# Altium schematic sheet OpAmps.SchDoc(OpAmps)
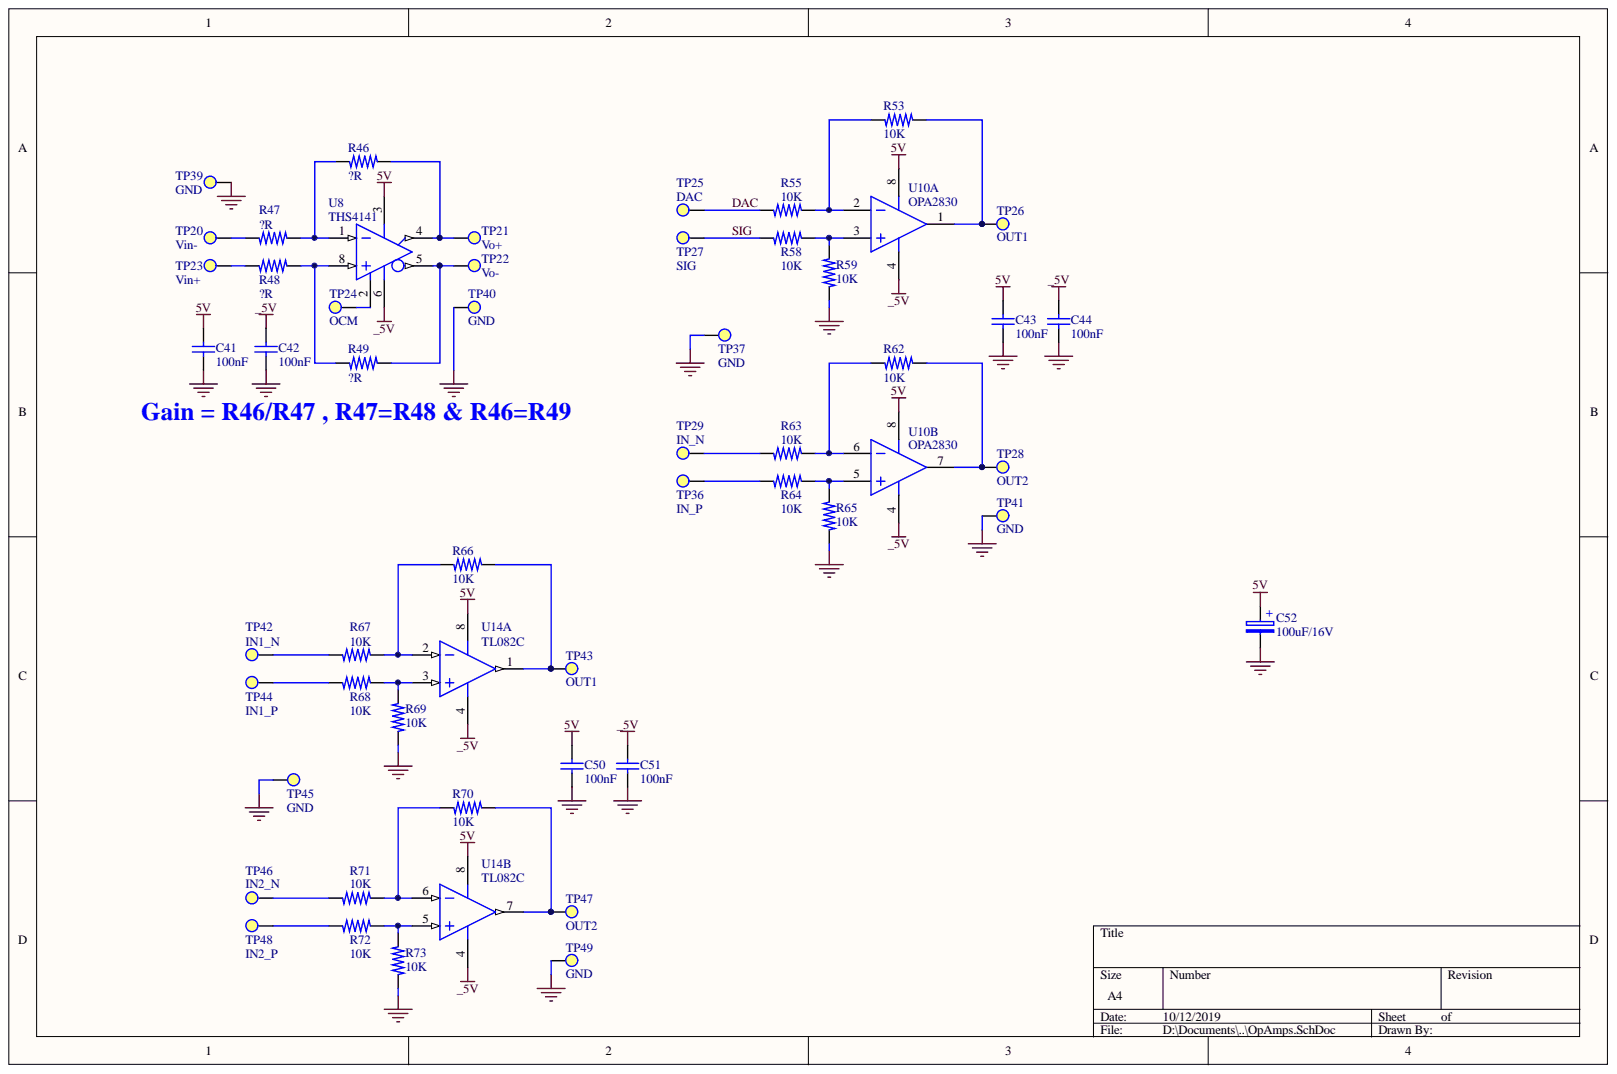
[diagram p0: OpAmps.SchDoc]

## Parts
C41  100nF  [0603_C]
C42  100nF  [0603_C]
C43  100nF  [0805Big_C]
C44  100nF  [0805Big_C]
C50  100nF  [0805Big_C]
C51  100nF  [0805Big_C]
C52  100uF/16V  [CAP_RAD_P04_D8x11]
R46  ?R  [0805Big_R]
R47  ?R  [0805Big_R]
R48  ?R  [0805Big_R]
R49  ?R  [0805Big_R]
R53  10K  [0805Big_R]
R55  10K  [0805Big_R]
R58  10K  [0805Big_R]
R59  10K  [0805Big_R]
R62  10K  [0805Big_R]
R63  10K  [0805Big_R]
R64  10K  [0805Big_R]
R65  10K  [0805Big_R]
R66  10K  [0805Big_R]
R67  10K  [0805Big_R]
R68  10K  [0805Big_R]
R69  10K  [0805Big_R]
R70  10K  [0805Big_R]
R71  10K  [0805Big_R]
R72  10K  [0805Big_R]
R73  10K  [0805Big_R]
TP20  Vin-  [PIN0MM9]
TP21  Vo+  [PIN0MM9]
TP22  Vo-  [PIN0MM9]
TP23  Vin+  [PIN0MM9]
TP24  OCM  [PIN0MM9]
TP25  DAC  [PIN0MM9]
TP26  OUT1  [PIN0MM9]
TP27  SIG  [PIN0MM9]
TP28  OUT2  [PIN0MM9]
TP29  IN_N  [PIN0MM9]
TP36  IN_P  [PIN0MM9]
TP37  GND  [PIN0MM9]
TP39  GND  [PIN0MM9]
TP40  GND  [PIN0MM9]
TP41  GND  [PIN0MM9]
TP42  IN1_N  [PIN0MM9]
TP43  OUT1  [PIN0MM9]
TP44  IN1_P  [PIN0MM9]
TP45  GND  [PIN0MM9]
TP46  IN2_N  [PIN0MM9]
TP47  OUT2  [PIN0MM9]
TP48  IN2_P  [PIN0MM9]
TP49  GND  [PIN0MM9]
U8  THS4141  [SOIC-8]
U10  OPA2830  [MSOP-8]  (parts A,B)
U14  TL082C  [SOIC-8]  (parts A,B)

## Nets
5V: C41.1 C43.1 C50.1 C52.1 U8.3 U10.8 U14.8
_5V: C42.1 C44.1 C51.1 U8.6 U10.4 U14.4
GND: C41.2 C42.2 C43.2 C44.2 C50.2 C51.2 C52.2 R59.1 R65.1 R69.1 R73.1 TP37.1 TP39.1 TP40.1 TP41.1 TP45.1 TP49.1
DAC: R55.1 TP25.1
SIG: R58.1 TP27.1
NetR46_1: R46.1 R47.2 U8.1
NetR46_2: R46.2 TP21.1 U8.4
NetR47_1: R47.1 TP20.1
NetR48_1: R48.1 TP23.1
NetR48_2: R48.2 R49.1 U8.8
NetR49_2: R49.2 TP22.1 U8.5
NetR53_1: R53.1 R55.2 U10.2
NetR53_2: R53.2 TP26.1 U10.1
NetR58_2: R58.2 R59.2 U10.3
NetR62_1: R62.1 R63.2 U10.6
NetR62_2: R62.2 TP28.1 U10.7
NetR63_1: R63.1 TP29.1
NetR64_1: R64.1 TP36.1
NetR64_2: R64.2 R65.2 U10.5
NetR66_1: R66.1 R67.2 U14.2
NetR66_2: R66.2 TP43.1 U14.1
NetR67_1: R67.1 TP42.1
NetR68_1: R68.1 TP44.1
NetR68_2: R68.2 R69.2 U14.3
NetR70_1: R70.1 R71.2 U14.6
NetR70_2: R70.2 TP47.1 U14.7
NetR71_1: R71.1 TP46.1
NetR72_1: R72.1 TP48.1
NetR72_2: R72.2 R73.2 U14.5
NetTP24_1: TP24.1 U8.2

## Library data of the parts
C41-C44 C50 C51 = CapCerSMD: Capacitor Ceramic SMD; Simulation: Model Name; Library Name: MyLibrary.IntLib; Component Kind: Standard
C52 = CapElectr: Capacitor Electrolytic; Library Name: Passive.SchLib; Component Kind: Standard
R46-R49 R53 R55 R58 R59 R62-R73 = ResSMD: Resistor SMD; Simulation: Model Name; Library Name: MyLibrary.IntLib; Component Kind: Standard
TP20-TP29 TP36 TP37 TP39-TP49 = PIN_TEST: Test Point; Library Name: MyLibrary.IntLib; Component Kind: Standard
U8 = THS4141: Op Amp, Single&Dual supply ±16.5V, Rail to Rail NO, 150MHz, 450V/µs; Library Name: AnalogIC.SchLib; Component Kind: Standard
U10 = OPA2830: Op Amp, Single&Dual supply, 100MHz, 500V/µs; Library Name: MyLibrary.IntLib; Component Kind: Standard
U14 = TL082C: JFET-Input Operational Amplifier; Published: 17-Jul-2002; PackageReference: 751-02; PackageDescription: 8-Pin Small Outline Integrated Circuit 1.27 mm Pitch; Library Name: MyLibrary.IntLib; LatestRevisionNote: IPC-7351 Footprint Added.; LatestRevisionDate: 13-Apr-2006; DatasheetVersion: 1988; ComponentLink1URL: http://www.onsemi.com/site/products/parametrics/0,4446,251,00.html; ComponentLink1Description: Manufacturer Link; Component Kind: Standard; Code_JEDEC: MS-012-AA; Code_IPC: SOIC127P600-8; Publisher: Altium Limited
